# Altium schematic sheet 02-Power_Supply.SchDoc
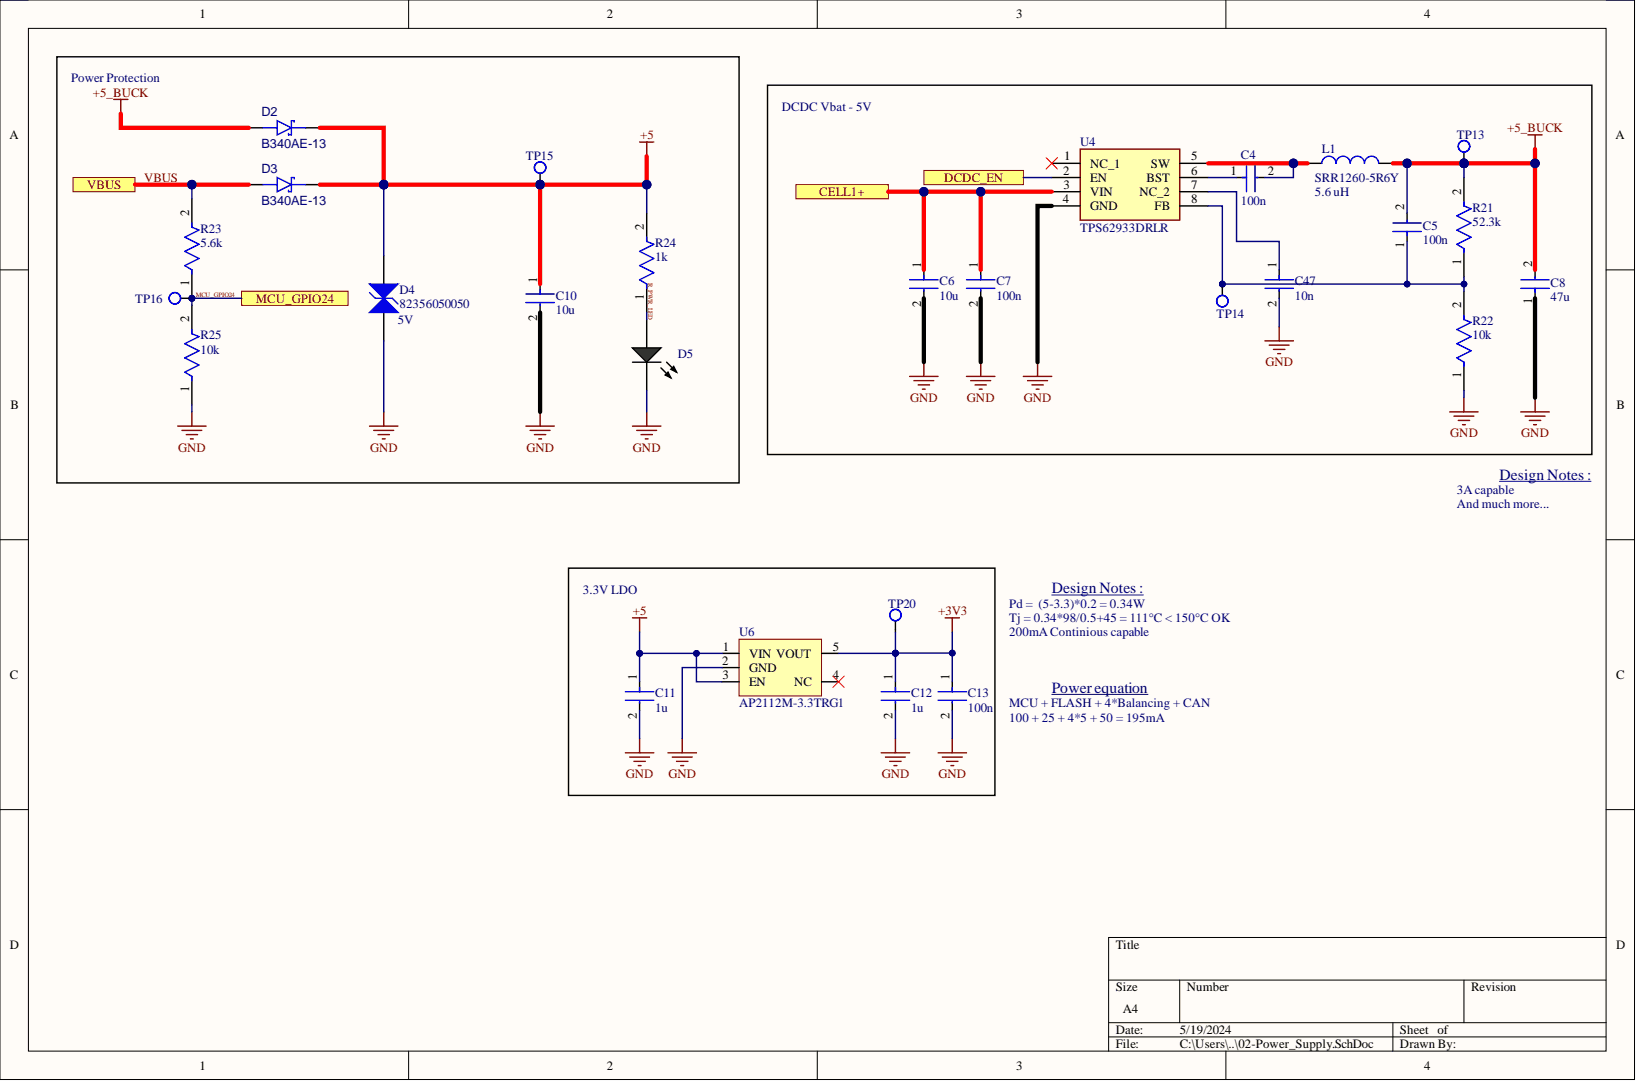
[diagram p0: 02-Power_Supply.SchDoc]

## Parts
C4  100n  [FP-CL21-IPC_A]
C5  100n  [FP-CL21-IPC_A]
C6  10u  [FP-CL21-IPC_A]
C7  100n  [FP-CL21-IPC_A]
C8  47u  [FP-CL21-IPC_A]
C10  10u  [FP-CL21-IPC_A]
C11  1u  [FP-CC0805-0_85-MFG]
C12  1u  [FP-CC0805-0_85-MFG]
C13  100n  [FP-CL21-IPC_A]
C47  10n  [FP-CC0805-0_85-MFG]
D2  B340AE-13  [FAIR-DO-214AC-2_V]
D3  B340AE-13  [FAIR-DO-214AC-2_V]
D4  82356050050  value 5V  Operating Supply Voltage: 5V  [WE-VE_0603]
D5  HSMG-C170  [AVAG-HSMX-C170_V]  (value not drawn)
L1  SRR1260-5R6Y  value 5.6 uH  [INDP125125X65N]
R21  52.3k  [FP-RT0805-MFG]
R22  10k  [FP-RT0805-MFG]
R23  5.6k  [FP-RT0805-MFG]
R24  1k  [FP-RT0805-MFG]
R25  10k  [FP-RT0805-MFG]
TP13  Test point  [Test point-Footprint-1]  (value not drawn)
TP14  Test point  [Test point-Footprint-1]  (value not drawn)
TP15  Test point  [Test point-Footprint-1]  (value not drawn)
TP16  Test point  [Test point-Footprint-1]  (value not drawn)
TP20  Test point  [Test point-Footprint-1]  (value not drawn)
U4  TPS62933DRLR  [SOTFL50P160X60-8N]
U6  AP2112M-3.3TRG1  [SOT95P245X110-5N]

## Ports
CELL1+, DCDC_EN, MCU_GPIO24, VBUS

## Nets
+3V3: C12.1 C13.1 TP20.1 U6.5(VOUT)
+5: C10.1 C11.1 D2.1 D3.1 D4.2 R24.2 TP15.1 U6.1(VIN) U6.3(EN)
+5_BUCK: C5.2 C8.2 D2.2 L1.2 R21.2 TP13.1
GND: C6.2 C7.2 C8.1 C10.2 C11.2 C12.2 C13.2 C47.2 D4.1 D5.2 R22.1 R25.1 U4.4(GND) U6.2(GND)
CELL1+: C6.1 C7.1 U4.3(VIN) ; port CELL1+
DCDC_EN: U4.2(EN) ; port DCDC_EN
MCU_GPIO24: R23.1 R25.2 TP16.1 ; port MCU_GPIO24
R_PWR_LED: D5.1 R24.1
VBUS: D3.2 R23.2 ; port VBUS
NetC4_1: C4.1 U4.6(BST)
NetC4_2: C4.2 L1.1 U4.5(SW)
NetC5_1: C5.1 R21.1 R22.2 TP14.1 U4.8(FB)
NetC47_1: C47.1 U4.7(NC_2)

Not connected: U4 1(NC_1); U6 4(NC)

## Library data of the parts
C4-C8 C10 C13 = CMP-13271-002172-1: CL21 Series 0805 10uF 25V ±10% Tolerance X5R Multilayer Ceramic Chip Capacitor; Capacitance: 10uF; Case Code (Imperial): 0805; Case Code (Metric): 2012; Case/Package: 0805; Component Kind: Standard; Depth: 1.25mm; Dielectric: X5R; Height: 0.85mm; Lead Free: Lead Free; Length: 2mm; Library Name: Altium Content Vault; Max Operating Temperature: 85°C; Min Operating Temperature: -55°C; Mount: Surface Mount; Package Quantity: 1; Packaging: Tape and Reel; Radiation Hardening: No; Thickness: 0.037inch; Tolerance: 10%; Voltage Rating: 25V; Width: 0.049inch
  C4 C7 C13: LCSC_Part_#: C49678
  C6 C10: LCSC_Part_#: C88484
  C8: LCSC_Part_#: C437557
C11 C12 C47 = CMP-1036-04410-2: CAP CER 0.1UF 25V X7R 0805; Manufacturer: Yageo; Manufacturer Part Number: CC0805KRX7R8BB104; Capacitance: 100 nF; Material: Ceramic; Tolerance: 0.1; Value: 100 nF; Automotive: No; Category: Cap; Component Kind: Standard; ComponentLink2Description: Datasheet; ComponentLink2URL: http://www.yageo.com/documents/recent/UPY-GPHC_Y5V_6.3V-to-50V_8.pdf; Device Class L1: Passive Components; Device Class L2: Capacitors; Device Class L3: Ceramic Capacitors; Digikey Description: CAP CER 0.1UF 25V X7R 0805; Footprint Url: http://www.yageo.com/exep/pages/download/literatures/UPY-C_GEN_24.pdf; Height: 0.95mm; Ipc Land Pattern Name: CAPC200125X85; Lead Free: Yes; Library Name: Altium Content Vault; Mouser Description: Multilayer Ceramic Capacitors MLCC - SMD/SMT 100nF 25V X7R 10%; Octopart: https://octopart.com/cc0805krx7r8bb104-yageo-39467766; Package: CC0805-0.85; RoHS: TRUE; Temperature: -30°C to +85°C; Temperature Characteristic: X7R; Temperature Range High: +85°C; Temperature Range Low: -30°C; Voltage Rating: 25 V
  C11 C12: LCSC_Part_#: C28323
  C47: LCSC_Part_#: C1710
D2 D3 = CMP-004-00034-1: Rectifier Diode, Schottky, 1 Phase, 1 Element, 3A, 40V V(RRM), Silicon; Component Kind: Standard; LCSC_Part_#: C168859; RoHS Compliant: Yes; Library Name: Clement Verda
D4 = CMP-1422-00013-2: NexarPartId: 75574476; Insulation Resistance: 10MΩ; Leakage Current: 1µA; Case Code (Metric): 0603; Case/Package: 0603; Component Kind: Standard; Height: 900µm; LCSC_Part_#: C9900055762; Length: 1.6mm; Library Name: Altium Content Vault; REACH SVHC: No SVHC; RoHS: Non-Compliant; Width: 800µm
D5 = CMP-2000-05062-1: Chip LED, Green, 2.2 V, -40 to 85 degC, 2-Pin SMD, Pb-Free, Tape and Reel; Manufacturer: Avago; Bulb Size: 1.25mmx1.4mm; Dominant Wavelength: 572nm; External Depth: 0.8mm; External Length / Height: 2mm; External Width: 1.25mm; Forward Current If: 20mA; Forward Voltage: 2.2V; Forward Voltage VF Max: 2.6V; LED Type: Standard; Lens Shape: Rectangular; Light Area Height: 1mm; Luminous Intensity: 15mcd; Luminous Intensity Min: 4mcd; No. of Pins: 2; Opto Mounting Orientation: Top; Peak Wavelength: 570nm; PIV Max: 5V; SVHC: NoSVHC(16-Jun-2014); Viewing Angle: 170deg; Component Kind: Standard; Datasheet URL: https://datasheet.ciiva.com/29280/180495-da-01-en-chip-led-hsmg-c170-gelb-gruen-29280971.pdf; Datasheet Version: Oct-08; Dominant Wavelength Typ: 572nm; Forward Current If Max: 20mA; LCSC_Part_#: C84256; LED Colour: Green; LED Mounting: SMD; Library Name: Altium Content Vault; Luminous Intensity Typ: 15mcd; Manufacturer URL: http://www.avagotech.com/; Mounting Type: SMD; MSL: MSL2A-4weeks; Operating Temperature Max: 85degC; Operating Temperature Min: -30degC; Operating Temperature Range: -30degCto+85degC; Opto Case Style: SMD; Package Description: 2-Pin Surface Mount Device, Body 2 x 1.25 mm, Height 0.8 mm; Package Reference: HSMX-C170; Packaging: CutTape; Peak Forward Current: 20mA; Storage Temperature Max: 85degC; Storage Temperature Min: -40degC; Voltage Vf Typ: 2.2V; Wavelength Typ: 570nm
L1 = CMP-006-00021-1: General Purpose Inductor, 5.6uH, 30%, 1 Element, Ferrite-Core, SMD, 4949; Case/Size Code: 5050; Max Current Rating: 6.9A; Self Resonant Frequency: 33MHz; Component Kind: Standard; LCSC_Part_#: C2761913; Max Operating Temperature: 125°C; Min Operating Temperature: -40°C; Pins: 2; RoHS Compliant: Yes; Tolerance: 30%; Library Name: Clement Verda
R21 R22 R24 = CMP-03412-005354-1: RES SMD 470 OHM 0.5% 1/8W 0805; Manufacturer: Yageo; Manufacturer Part Number: RT0805DRE074K7L; Power Rating: 0.125W; Resistance: 1kΩ; Temperature Coefficient: 50 ppm/°C; Tolerance: 0.01; Value: 1kΩ; Voltage Rating: 150V; Automotive: No; Category: Res; Component Kind: Standard; ComponentLink2Description: Datasheet; ComponentLink2URL: http://www.yageo.com/documents/recent/PYu-RT_1-to-0.01_RoHS_L_9.pdf; Composition: Thin Film; Device Class L1: Passive Components; Device Class L2: Resistors; Device Class L3: Chip SMD Resistors; Digikey Description: RES SMD 4.7K OHM 0.5% 1/8W 0805; Footprint Url: http://www.yageo.com/exep/pages/download/literatures/PYu-R_Mount_10.pdf; Height: 0.6mm; Ipc Land Pattern Name: RESC200125X50; Lead Free: Yes; Library Name: Altium Content Vault; Octopart: https://octopart.com/rt0805dre074k7l-yageo-1242027; Package: RT0805; RoHS: TRUE; Series: RT; Temperature: -55°C to +155°C; Temperature Range High: +155°C; Temperature Range Low: -55°C
  R21: LCSC_Part_#: C218616
  R22: LCSC_Part_#: C17414
  R24: LCSC_Part_#: C17513
R23 = CMP-03412-005354-1: RES SMD 5.6K OHM 1% 1/8W 0805; Manufacturer: Yageo; Manufacturer Part Number: RT0805DRE074K7L; Power Rating: 0.125W; Resistance: 5.6KΩ; Temperature Coefficient: 50 ppm/°C; Tolerance: 0.01; Value: 5.6KΩ; Voltage Rating: 150V; Automotive: No; Category: Res; Component Kind: Standard; ComponentLink2Description: Datasheet; ComponentLink2URL: http://www.yageo.com/documents/recent/PYu-RT_1-to-0.01_RoHS_L_9.pdf; Composition: Thin Film; Device Class L1: Passive Components; Device Class L2: Resistors; Device Class L3: Chip SMD Resistors; Digikey Description: RES SMD 4.7K OHM 0.5% 1/8W 0805; Footprint Url: http://www.yageo.com/exep/pages/download/literatures/PYu-R_Mount_10.pdf; Height: 0.6mm; Ipc Land Pattern Name: RESC200125X50; LCSC_Part_#: C4382; Lead Free: Yes; Library Name: Altium Content Vault; Octopart: https://octopart.com/rt0805dre074k7l-yageo-1242027; Package: RT0805; RoHS: TRUE; Series: RT; Temperature: -55°C to +155°C; Temperature Range High: +155°C; Temperature Range Low: -55°C
R25 = CMP-03412-005354-1: RES SMD 10K OHM 1% 1/8W 0805; Manufacturer: Yageo; Manufacturer Part Number: RT0805DRE074K7L; Power Rating: 0.125W; Resistance: 10KΩ; Temperature Coefficient: 50 ppm/°C; Tolerance: 0.01; Value: 10KΩ; Voltage Rating: 150V; Automotive: No; Category: Res; Component Kind: Standard; ComponentLink2Description: Datasheet; ComponentLink2URL: http://www.yageo.com/documents/recent/PYu-RT_1-to-0.01_RoHS_L_9.pdf; Composition: Thin Film; Device Class L1: Passive Components; Device Class L2: Resistors; Device Class L3: Chip SMD Resistors; Digikey Description: RES SMD 4.7K OHM 0.5% 1/8W 0805; Footprint Url: http://www.yageo.com/exep/pages/download/literatures/PYu-R_Mount_10.pdf; Height: 0.6mm; Ipc Land Pattern Name: RESC200125X50; LCSC_Part_#: C17414; Lead Free: Yes; Library Name: Altium Content Vault; Octopart: https://octopart.com/rt0805dre074k7l-yageo-1242027; Package: RT0805; RoHS: TRUE; Series: RT; Temperature: -55°C to +155°C; Temperature Range High: +155°C; Temperature Range Low: -55°C
TP13-TP16 TP20 = CMP-002-00075-1: Component Kind: Standard; Description: test point; Library Name: Clement Verda
U4 = CMP-010-000000-2: TPS563300 3.8-V to 28-V, 3-A Synchronous Buck Converter in SOT583 Package; Manufacturer_Name: Texas Instruments; Manufacturer_Part_Number: TPS563300DRLR; Geometry.Height: 0.6mm; Component Kind: Standard; Datasheet Link: https://www.ti.com/lit/ds/symlink/tps563300.pdf?ts=1666035990155&ref_url=https%253A%252F%252Fwww.ti.com%252Fproduct%252FTPS563300; Design Item ID: TPS563300DRLR; LCSC_Part_#: C3200405; Mouser Part Number: 595-TPS563300DRLR; Mouser Price/Stock: https://www.mouser.co.uk/ProductDetail/Texas-Instruments/TPS563300DRLR?qs=vvQtp7zwQdMDxJAw0dSlAg%3D%3D; Source: TPS563300DRLR.SchLib; Library Name: Clement Verda
U6 = CMP-019-00049-2: Fixed Positive LDO Regulator, 3.3V, 0.4V Dropout, CMOS, PDSO8; Max Supply Voltage: 6V; Case/Package: SOP; Component Kind: Standard; LCSC_Part_#: C5290219; Max Operating Temperature: 85°C; Min Operating Temperature: -40°C; Pins: 8; RoHS Compliant: Yes; Library Name: Clement Verda
